# Altium schematic sheet Sheet1.SchDoc(Sheet1)
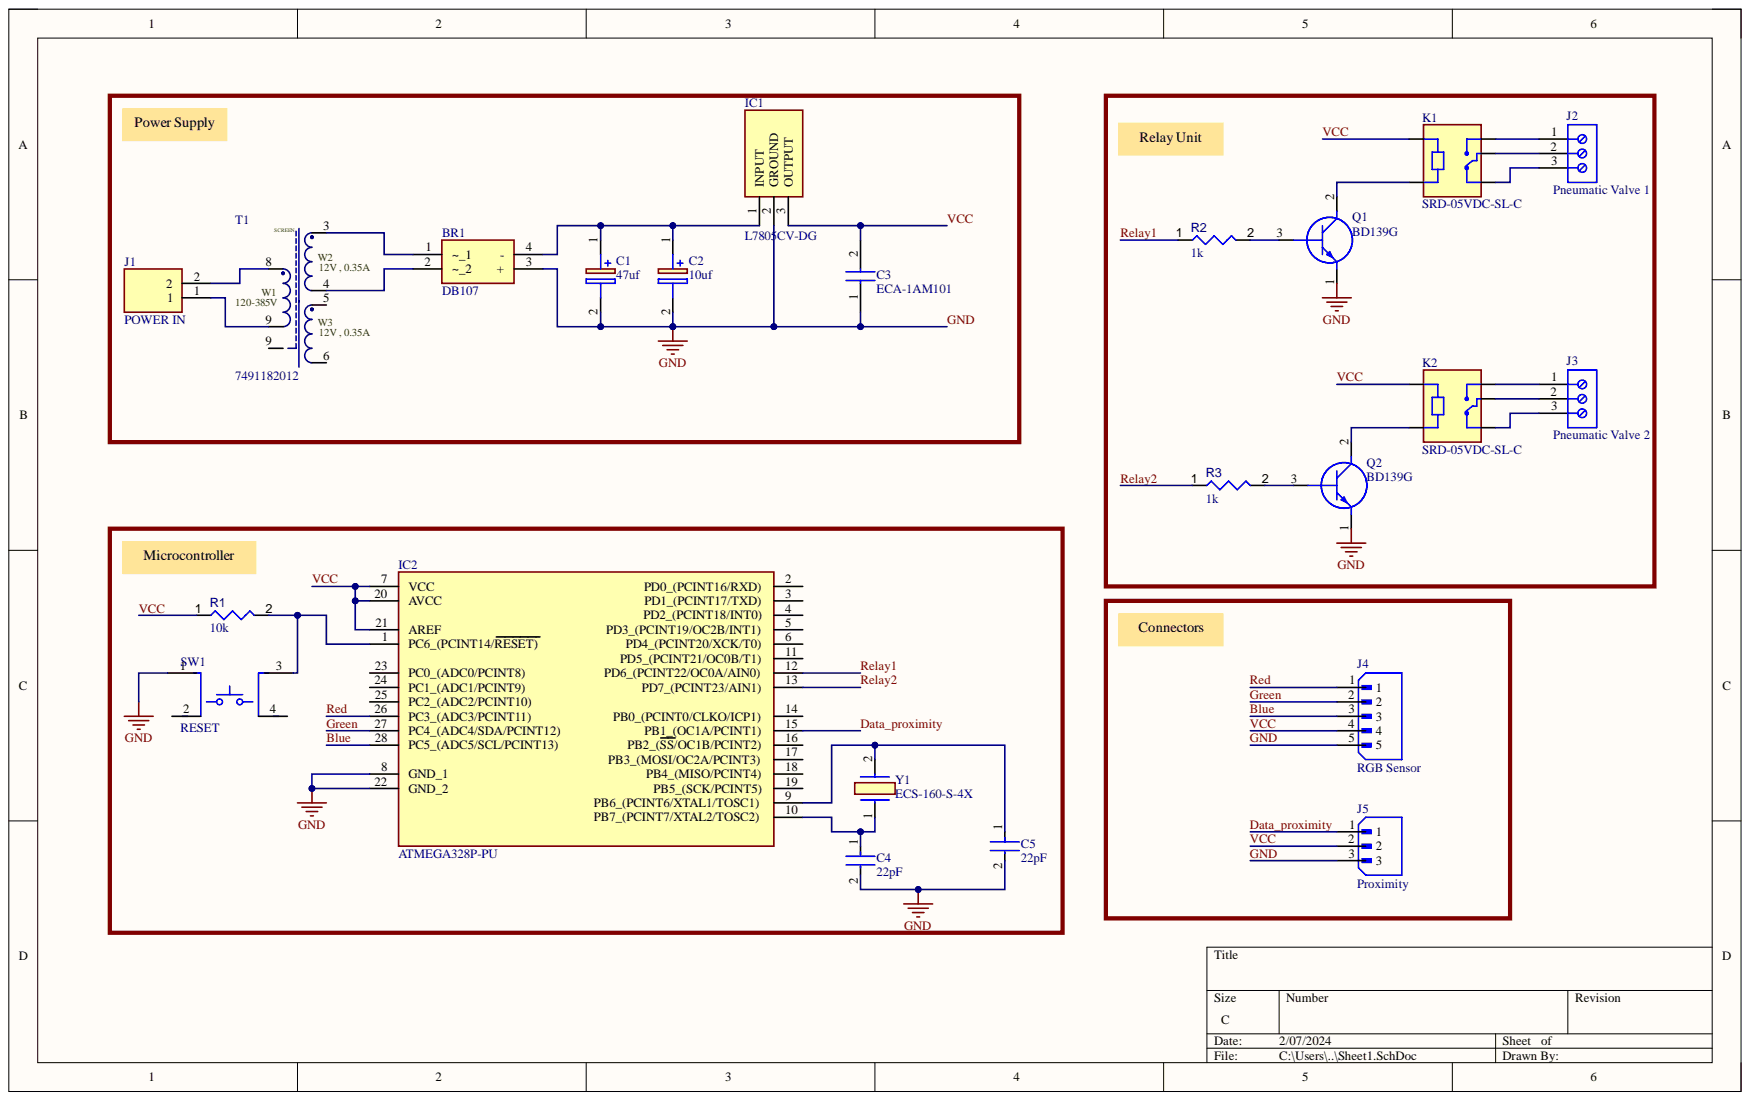
[diagram p0: Sheet1.SchDoc]

## Parts
BR1  DB107  [DIP825W50P510L830H340Q4N]
C1  47uf  [CAPPRD250W55D630H1220]
C2  10uf  [CAPPRD200W55D500H1200]
C3  ECA-1AM101  [CAPPRD200W55D525H1200]
C4  22pF  [FP-RCE0DB-MFG]
C5  22pF  [FP-RCE0DB-MFG]
IC1  L7805CV-DG  [TO255P460X1020X2008-3P]
IC2  ATMEGA328P-PU  [DIP794W53P254L3467H457Q28N]
J1  POWER IN  [TB00250002BE]
J2  Pneumatic Valve 1  [691101710003]
J3  Pneumatic Valve 2  [691101710003]
J4  RGB Sensor  [JST_B5B-XH-A(LF)(SN)]
J5  Proximity  [JST_B3B-XH-A (LF)(SN)]
K1  SRD-05VDC-SL-C  [RELAY_SRD-05VDC-SL-C]
K2  SRD-05VDC-SL-C  [RELAY_SRD-05VDC-SL-C]
Q1  BD139G  [TO229P300X760X1364-3P]
Q2  BD139G  [TO229P300X760X1364-3P]
R1  value 10k  [RESA60-630X240]  (hidden comment: MFR-25FBF52-10K)
R2  value 1k  [RESA60-630X240]  (hidden comment: MFR-25FBF52-1K)
R3  value 1k  [RESA60-630X240]  (hidden comment: MFR-25FBF52-1K)
SW1  RESET  [FP-SKQBARA010-MFG]
T1  7491182012  [EE16_7_4 (E16)]
Y1  ECS-160-S-4X  [ECS160S4X]

## Nets
Blue: IC2.28(PC5_(ADC5/SCL/PCINT13)) J4.3
Data_proximity: IC2.15(PB1_(OC1A/PCINT1)) J5.1
GND: BR1.3(+) C1.2 C2.2 C3.1 C4.2 C5.2 IC1.2 IC2.8(GND_1) IC2.22(GND_2) J4.5 J5.3 Q1.1 Q2.1 SW1.1
Green: IC2.27(PC4_(ADC4/SDA/PCINT12)) J4.2
Red: IC2.26(PC3_(ADC3/PCINT11)) J4.1
Relay1: IC2.12(PD6_(PCINT22/OC0A/AIN0)) R2.1
Relay2: IC2.13(PD7_(PCINT23/AIN1)) R3.1
VCC: C3.2 IC1.3 IC2.7(VCC) IC2.20(AVCC) IC2.21(AREF) J4.4 J5.2 K1.A1 K2.A1 R1.1
NetBR1_1: BR1.1(~_1) T1.3
NetBR1_2: BR1.2(~_2) T1.4
NetBR1_4: BR1.4(-) C1.1 C2.1 IC1.1
NetC4_1: C4.1 IC2.10(PB7_(PCINT7/XTAL2/TOSC2)) Y1.1
NetC5_1: C5.1 IC2.9(PB6_(PCINT6/XTAL1/TOSC1)) Y1.2
NetIC2_1: IC2.1(PC6_(PCINT14/RESET)) R1.2 SW1.3
NetJ1_1: J1.1 T1.9
NetJ1_2: J1.2 T1.8
NetJ2_1: J2.1 K1.NO
NetJ2_2: J2.2 K1.COM
NetJ2_3: J2.3 K1.NC
NetJ3_1: J3.1 K2.NO
NetJ3_2: J3.2 K2.COM
NetJ3_3: J3.3 K2.NC
NetK1_A2: K1.A2 Q1.2
NetK2_A2: K2.A2 Q2.2
NetQ1_3: Q1.3 R2.2
NetQ2_3: Q2.3 R3.2

Not connected: IC2 2(PD0_(PCINT16/RXD)) 3(PD1_(PCINT17/TXD)) 4(PD2_(PCINT18/INT0)) 5(PD3_(PCINT19/OC2B/INT1)) 6(PD4_(PCINT20/XCK/T0)) 11(PD5_(PCINT21/OC0B/T1)) 14(PB0_(PCINT0/CLKO/ICP1)) 16(PB2_(SS/OC1B/PCINT2)) 17(PB3_(MOSI/OC2A/PCINT3)) 18(PB4_(MISO/PCINT4)) 19(PB5_(SCK/PCINT5)) 23(PC0_(ADC0/PCINT8)) 24(PC1_(ADC1/PCINT9)) 25(PC2_(ADC2/PCINT10)); SW1 2 4; T1 5 6

## Library data of the parts
BR1 = DB107: Bridge Rectifier; Manufacturer_Name: Rectron; Component Kind: Standard; Datasheet Link: https://www.rectron.com/data_sheets/db101-107.pdf; Height: 3.4mm; Manufacturer_Part_Number: DB107; Mouser Part Number: 583-DB107; Mouser Price/Stock: https://www.mouser.co.uk/ProductDetail/Rectron/DB107?qs=G5AQjGfRJcLGAY%252B6bEDnbw%3D%3D
C1 = ECE-A1EN470UB: Capacitor Polarised; Manufacturer_Name: Panasonic; Component Kind: Standard; Datasheet Link: http://industrial.panasonic.com/cdbs/www-data/pdf/RDF0000/ABA0000C1053.pdf; Height: 12.2mm; Manufacturer_Part_Number: ECE-A1EN470UB; Mouser Part Number: 667-ECE-A1EN470UB; Mouser Price/Stock: https://www.mouser.co.uk/ProductDetail/Panasonic/ECE-A1EN470UB?qs=rMMd5vBiahp%252B1Xr0dP1Lmg%3D%3D
C2 = ECA-1EM100: Capacitor Polarised; Manufacturer_Name: Panasonic; Arrow Part Number: ECA-1EM100; Arrow Price/Stock: https://www.arrow.com/en/products/eca1em100/panasonic; Component Kind: Standard; Datasheet Link: https://componentsearchengine.com/Datasheets/2/ECA-1EM100.pdf; Height: 12mm; Manufacturer_Part_Number: ECA-1EM100; Mouser Part Number: 667-ECA-1EM100; Mouser Price/Stock: https://www.mouser.com/Search/Refine.aspx?Keyword=667-ECA-1EM100
C3 = ECA-1AM101: Capacitor; Manufacturer_Name: Panasonic; Arrow Part Number: ECA-1AM101; Arrow Price/Stock: https://www.arrow.com/en/products/eca-1am101/panasonic?region=nac; Component Kind: Standard; Datasheet Link: http://uk.rs-online.com/web/p/products/8073233; Height: 12mm; Manufacturer_Part_Number: ECA-1AM101; Mouser Part Number: 667-ECA-1AM101; Mouser Price/Stock: https://www.mouser.co.uk/ProductDetail/Panasonic/ECA-1AM101?qs=o8jAzVTWolupLSuBsMrKhQ%3D%3D
C4 C5 = CMP-06035-047347-1: Cap Ceramic 22pF 100V C0G ±5% Radial 2.5mm +125°C Ammo Pack; Lead Diameter: 0.5mm; Lead/Base Style: Straight; Capacitance: 22pF; Case/Package: Radial; Component Kind: Standard; Depth: 2.5mm; Dielectric: C0G; Height: 3.5mm; Height - Seated (Max): 0.138inch; Lead Pitch: 0.098inch; Length: 3.6mm; Library Name: Altium Content Vault; Max Operating Temperature: 125°C; Min Operating Temperature: -55°C; Mount: Through Hole; Package Quantity: 2000; Packaging: Tape and Reel; Ratings: AEC-Q200; Resistor Type: Ceramic; Termination: Radial; Tolerance: 5%; Voltage: 100V; Voltage Rating: 100V; Voltage Rating (DC): 100V; Width: 0.098inch
IC1 = L7805CV-DG: Integrated Circuit; Manufacturer_Name: STMicroelectronics; Arrow Part Number: L7805CV-DG; Arrow Price/Stock: https://www.arrow.com/en/products/l7805cv-dg/stmicroelectronics?region=europe; Component Kind: Standard; Datasheet Link: http://www.st.com/web/en/resource/technical/document/datasheet/CD00000444.pdf; Height: 4.6mm; Manufacturer_Part_Number: L7805CV-DG; Mouser Part Number: 511-L7805CV-DG; Mouser Price/Stock: https://www.mouser.co.uk/ProductDetail/STMicroelectronics/L7805CV-DG?qs=T%2FOtf55vL7c3O0as9P9fAw%3D%3D
IC2 = ATMEGA328P-PU: Integrated Circuit; Manufacturer_Name: Microchip; Arrow Part Number: ATMEGA328P-PU; Arrow Price/Stock: https://www.arrow.com/en/products/atmega328p-pu/microchip-technology?region=europe; Component Kind: Standard; Datasheet Link: https://www.mouser.ca/datasheet/2/268/ATmega48A_PA_88A_PA_168A_PA_328_P_DS_DS40002061B-3050139.pdf; Height: 4.572mm; Manufacturer_Part_Number: ATMEGA328P-PU; Mouser Part Number: 556-ATMEGA328P-PU; Mouser Price/Stock: https://www.mouser.co.uk/ProductDetail/Microchip-Technology/ATMEGA328P-PU?qs=K8BHR703ZXguOQv3sKbWcg%3D%3D
J1 = TB002-500-02BE: Connector; Manufacturer_Name: CUI Devices; Arrow Part Number: TB002-500-02BE; Arrow Price/Stock: https://www.arrow.com/en/products/tb002-500-02be/cui-devices?region=nac; Component Kind: Standard; Datasheet Link: https://eu.mouser.com/datasheet/2/670/tb002_500-1550579.pdf; Height: 10.4mm; Manufacturer_Part_Number: TB002-500-02BE; Mouser Part Number: 490-TB002-500-02BE; Mouser Price/Stock: https://www.mouser.co.uk/ProductDetail/CUI-Devices/TB002-500-02BE?qs=vLWxofP3U2x9716kcgva%2Fw%3D%3D
J2 J3 = CMP-1502-03257-2: NexarPartId: 8917705; Current: 16A; Number of Contacts: 3; Number of Levels: 1; Pitch: 5.0038mm; Plating: Steel; Stripping Length: -7mm; Voltage: 250V; Wire Gauge (Max): 26AWG; Wire Gauge (Min): 14AWG; Color: Blue; Component Kind: Standard; Contact Material: Brass; Contact Plating: Tin; Current Rating: 16A; Height: 16.4mm; Housing Material: Nylon; Lead Pitch: 5mm; Length: 9mm; Library Name: Altium Content Vault; Max Operating Temperature: 105°C; Min Operating Temperature: -40°C; Mount: Through Hole; Number of Pins: 3; Number of Positions Per Level: 3; Packaging: Box; REACH SVHC: Unknown; RoHS: Compliant; Termination: Screw; Voltage Rating: 250V; Width: 16.2mm; Wire/Cable Gauge: 26AWG
J4 = B5B-XH-A(LF)(SN): Connector Header Through Hole 5 position 0.098 (2.50mm); MF: JST Sales America Inc.; Check_prices: https://www.snapeda.com/parts/B5B-XH-A(LF)(SN)/JST+Sales+America+Inc./view-part/?ref=eda; Component Kind: Standard; Library Name: B5B-XH-A_LF__SN_.IntLib; MP: B5B-XH-A(LF)(SN); Package: None; SnapEDA_Link: https://www.snapeda.com/parts/B5B-XH-A(LF)(SN)/JST+Sales+America+Inc./view-part/?ref=snap
J5 = B3B-XH-A_(LF)(SN): Connector Header Through Hole 3 position 0.098 (2.50mm); MF: JST Sales America Inc.; MP: B3B-XH-A (LF)(SN); Check_prices: https://www.snapeda.com/parts/B3B-XH-A%20(LF)(SN)/JST+Sales+America+Inc./view-part/?ref=eda; Component Kind: Standard; Library Name: B3B-XH-A__LF__SN_.IntLib; Package: None; SnapEDA_Link: https://www.snapeda.com/parts/B3B-XH-A%20(LF)(SN)/JST+Sales+America+Inc./view-part/?ref=snap
K1 K2 = SRD-05VDC-SL-C: 5V Trigger Relay Module For Arduino And Raspberry Pi 5V Trigger Relay Module For Arduino And Raspberry Pi; MF: Songle Relay; Availability: Not in stock; Check_prices: https://www.snapeda.com/parts/SRD-05VDC-SL-C/Songle+Relay/view-part/?ref=eda; Component Kind: Standard; Library Name: SRD-05VDC-SL-C.IntLib; MP: SRD-05VDC-SL-C; Package: NON STANDARD-5 Songle Relay; Price: None; SnapEDA_Link: https://www.snapeda.com/parts/SRD-05VDC-SL-C/Songle+Relay/view-part/?ref=snap
Q1 Q2 = BD139G: Transistor BJT NPN; Manufacturer_Name: onsemi; Arrow Part Number: BD139G; Arrow Price/Stock: https://www.arrow.com/en/products/bd139g/on-semiconductor?region=nac; Component Kind: Standard; Datasheet Link: http://www.onsemi.com/pub/Collateral/BD135-D.PDF; Height: 3mm; Manufacturer_Part_Number: BD139G; Mouser Part Number: 863-BD139G; Mouser Price/Stock: https://www.mouser.co.uk/ProductDetail/onsemi/BD139G?qs=vNc2DXHODiLIqGggNMT6cg%3D%3D
R1 = CMP-009-00047-4: Axial Resistor, 10 KOhm, +/- 1%, 0.25 W, -55 to 155 degC, 2-Pin THD, RoHS, Bulk; Manufacturer: Yageo; Power: 250mW; Voltage Rating: 250V; Case/Package: Axial; Component Kind: Standard; Datasheet URL: http://www.yageo.com/documents/recent/Yageo%20LR_MFR_2013.pdf; Datasheet Version: Apr-13; Manufacturer URL: http://www.yageo.com/; Max Operating Temperature: 155°C; Min Operating Temperature: -55°C; Mounting Technology: TH; Package Description: 2-Pin Axial Lead, Dia 2.4 mm, Body Length 6.3 mm; Package Quantity: 200; Package Reference: MFR-25; Packaging: Bulk; RoHS Compliant: True; Tolerance: 1%; Library Name: Nidula  Gunawardana
R2 R3 = CMP-009-00048-4: Axial Resistor, 1 KOhm, +/- 1%, 250 mW, -55 to 155 degC, 2-Pin THD, RoHS, Bulk; Manufacturer: Yageo; Power: 250mW; Voltage Rating: 250V; Case/Package: Axial; Component Kind: Standard; Composition: CarbonFilm; Datasheet URL: http://www.yageo.com/documents/recent/Yageo%20LR_MFR_2013.pdf; Datasheet Version: Apr-13; Manufacturer URL: http://www.yageo.com/; Max Operating Temperature: 155°C; Min Operating Temperature: -55°C; Mounting Technology: TH; Package Description: 2-Pin Axial Lead, Dia 2.4 mm, Body Length 6.3 mm; Package Quantity: 200; Package Reference: MFR-25; Packaging: Bulk; RoHS Compliant: True; Tolerance: 1%; Library Name: Nidula  Gunawardana
SW1 = CMP-00631-07849992-1: SKQBARA010 Switch Tactile N.O. SPST Round Button PC Pins 0.05A 12VDC 1.57N Thru-Hole Automotive; Actuator Length: 850µm; Contact Current Rating: 50mA; Contact Resistance: 500mΩ; Electrical Life: 100kcycles; Max Voltage Rating (DC): 12V; Number of Positions: 2; Color: Grey; Component Kind: Standard; Contact Plating: Silver; Current Rating: 50mA; Depth: 10.3mm; Height: 5mm; Lead Free: Lead Free; Length: 10mm; Library Name: Altium Content Vault; Life (Cycles): 100kcycles; Max Current Rating: 50mA; Max Operating Temperature: 90°C; Min Operating Temperature: -40°C; Mount: Through Hole; NexarPartId: 7849992; Operating Force: 1.57N; Orientation: Straight; Packaging: Bulk; Radiation Hardening: No; RoHS: Compliant; Schedule B: 8536509065, 8536509065; Termination: PC Pins; Throw Configuration: SPST; Voltage Rating (DC): 12V
T1 = CMP-1471-00001-1: Off-Line Transformer WE-UNIT , L=0.9mH; Manufacturer: Wuerth Elektronik; Inductance: 0.9mH; Input Voltage (AC): 85-265; Output Current (A): 0.6; Output Voltage (DC): 12; Turns Ratio: "9.5","1:1"; Component Kind: Standard; ComponentLink1Description: Manufacturer URL; ComponentLink1URL: http://www.we-online.com; ComponentLink2Description: Datasheet; ComponentLink2URL: http://www.we-online.de/katalog/media/pdf/7491182012.pdf; Library Name: Altium Content Vault; PackageReference: EE16_7_4 (E16); PartNumber: 7491182012
Y1 = ECS-160-S-4X: Crystal or Oscillator; Manufacturer_Name: ECS; Arrow Part Number: ECS-160-S-4X; Arrow Price/Stock: https://www.arrow.com/en/products/ecs-160-s-4x/ecs-international?region=nac; Component Kind: Standard; Datasheet Link: http://www.ecsxtal.com/store/pdf/hc-49usx.pdf; Height: 3.5mm; Manufacturer_Part_Number: ECS-160-S-4X; Mouser Part Number: 520-HCU1600-SX; Mouser Price/Stock: https://www.mouser.co.uk/ProductDetail/ECS/ECS-160-S-4X?qs=CNVyLAqdhH109We7RtSowA%3D%3D
